# Altium schematic sheet POWER.SchDoc(POWER)
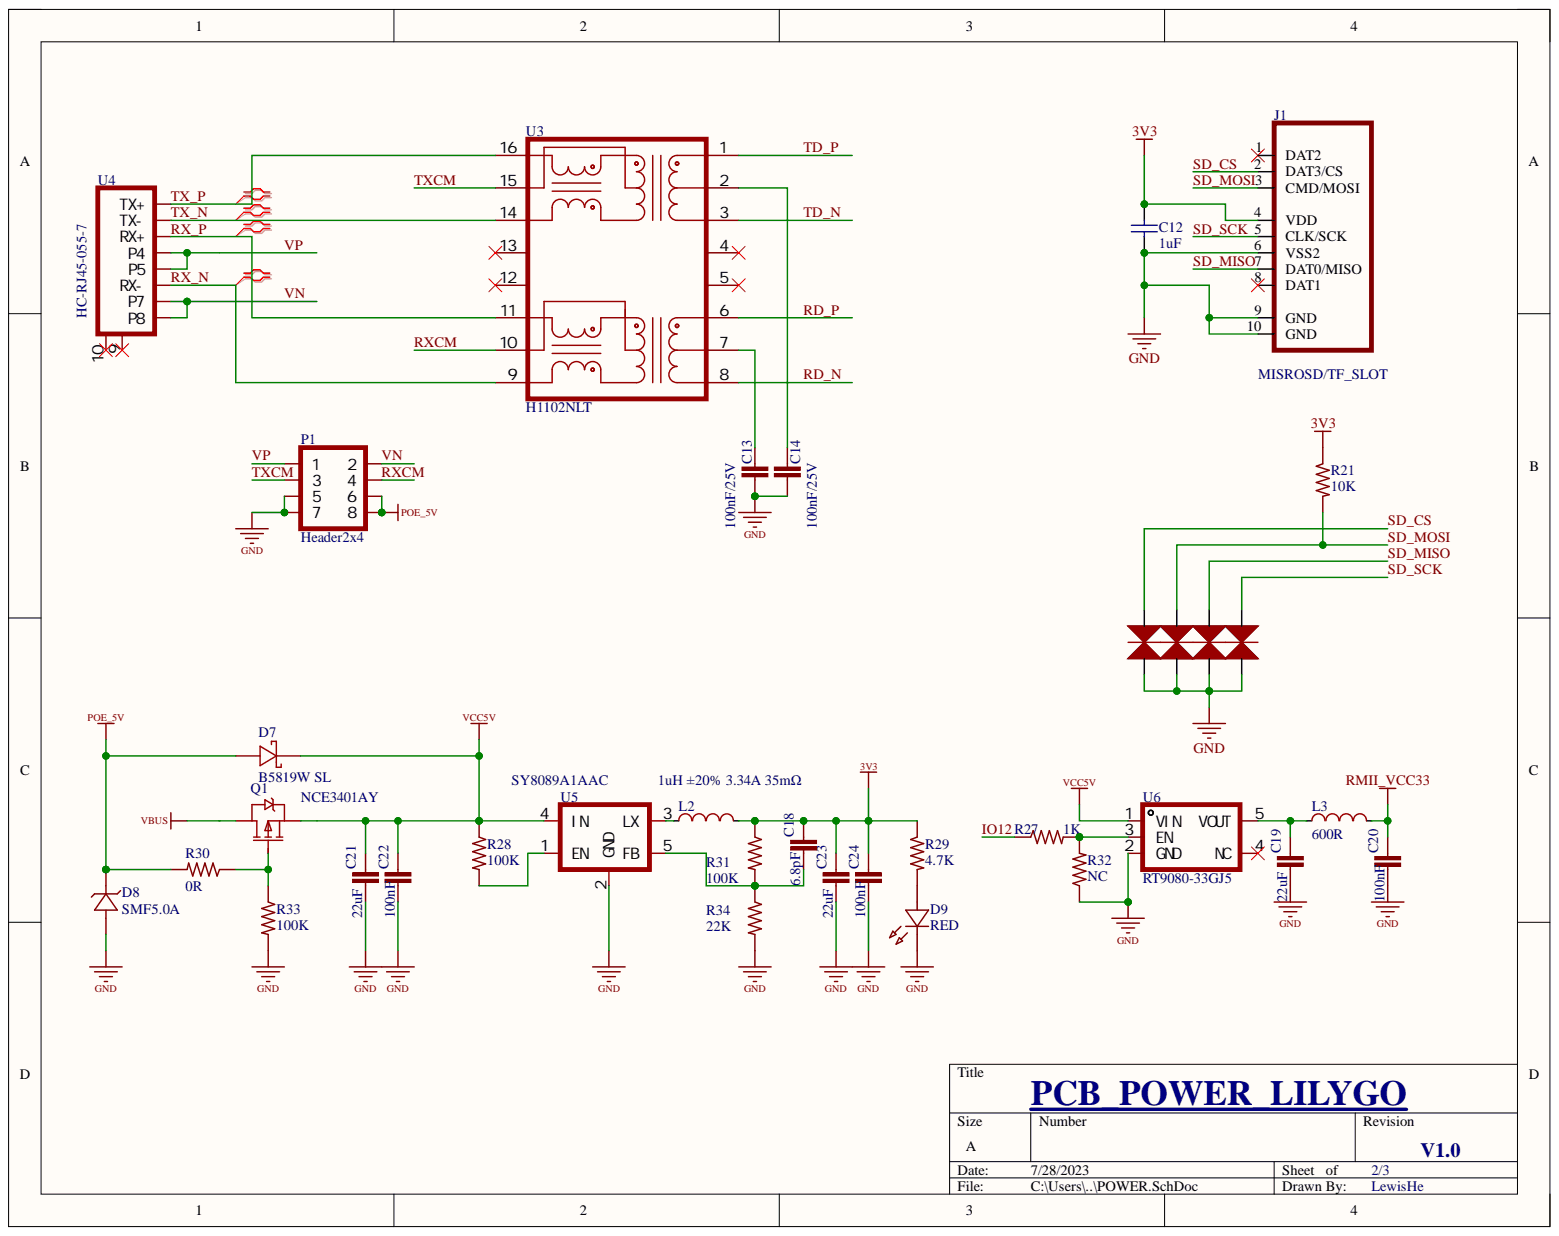
[diagram p0: POWER.SchDoc]

## Parts
C12  1uF  [C0402]
C13  100nF/25V  [c0603]
C14  100nF/25V  [c0603]
C18  6.8pF  [C0402]
C19  22uF  [C0603]
C20  100nF  [C0402]
C21  22uF  [C0603]
C22  100nF  [C0402]
C23  22uF  [C0603]
C24  100nF  [C0402]
D3  ESD05V88D-LC  [DFN-SMD_L1.0-W0.6-BI]  (value not drawn)
D4  ESD05V88D-LC  [DFN-SMD_L1.0-W0.6-BI]  (value not drawn)
D5  ESD05V88D-LC  [DFN-SMD_L1.0-W0.6-BI]  (value not drawn)
D6  ESD05V88D-LC  [DFN-SMD_L1.0-W0.6-BI]  (value not drawn)
D7  B5819W SL  [SOD-123_L2.7-W1.6-LS3.7-RD-1]
D8  SMF5.0A  [SOD-123FL_L2.7-W1.8-LS3.8-RD]
D9  RED  [LED0603_RED]
J1  MISROSD/TF_SLOT  [Micro_SD]
L2  1uH ±20% 3.34A 35mΩ  [IND-SMD_L3.2-W3.0_SPM3012T]
L3  600R  [L0603]
P1  Header2x4  [HDR-TH_8P-P2.54-V-F-R2-C4-S2.54-排针]
Q1  NCE3401AY  [SOT-23-3_L2.9-W1.6-P1.90-LS2.8-BR]
R21  10K  [R0402]
R27  1K  [R0402]
R28  100K  [R0402]
R29  4.7K  [R0402]
R30  0R  [R0402]
R31  100K  [R0402]
R32  NC  [R0402]
R33  100K  [R0402]
R34  22K  [R0402]
U3  H1102NLT  [XFMR-SMD_H1102NLT]
U4  HC-RJ45-055-7  [RJ45-SMD_HC-RJ45-055-7_1]
U5  SY8089A1AAC  [SOT-23-5_L2.9-W1.7-P0.95-LS2.8-BL]
U6  RT9080-33GJ5  [TSOT-23-5_L2.9-W1.6-P0.95-LS2.8-BL]

## Nets
3V3: C12.1 C18.2 C23.2 C24.2 J1.4(VDD) L2.2 R21.1 R29.1 R31.1
GND: C12.2 C13.1 C14.1 C19.1 C20.1 C21.1 C22.1 C23.1 C24.1 D3.2 D4.2 D5.2 D6.2 D8.2 D9.2 J1.6(VSS2) J1.9(GND) J1.10(GND) P1.5 P1.7 R32.1 R33.2 R34.2 U5.2(GND) U6.2(GND)
POE_5V: D7.2 D8.1 P1.6 P1.8 R30.2
RMII_VCC33: C20.2 L3.1
VBUS: Q1.3
VCC5V: C21.2 C22.2 D7.1 Q1.2 R28.1 U5.4(IN) U6.1(VIN)
IO12: R27.1
RD_N: U3.8
RD_P: U3.6
RX_N: U3.9 U4.6(RX-)
RX_P: U3.11 U4.3(RX+)
RXCM: P1.4 U3.10
SD_CS: D3.1 J1.2(DAT3/CS)
SD_MISO: D5.1 J1.7(DAT0/MISO)
SD_MOSI: D4.1 J1.3(CMD/MOSI) R21.2
SD_SCK: D6.1 J1.5(CLK/SCK)
TD_N: U3.3
TD_P: U3.1
TX_N: U3.14 U4.2(TX-)
TX_P: U3.16 U4.1(TX+)
TXCM: P1.3 U3.15
VN: P1.2 U4.7(P7) U4.8(P8)
VP: P1.1 U4.4(P4) U4.5(P5)
NetC13_2: C13.2 U3.7
NetC14_2: C14.2 U3.2
NetC18_1: C18.1 R31.2 R34.1 U5.5(FB)
NetC19_2: C19.2 L3.2 U6.5(VOUT)
NetD9_1: D9.1 R29.2
NetL2_1: L2.1 U5.3(LX)
NetQ1_1: Q1.1 R30.1 R33.1
NetR27_2: R27.2 R32.2 U6.3(EN)
NetR28_2: R28.2 U5.1(EN)

Not connected: J1 1(DAT2) 8(DAT1); U3 4 5 12 13; U4 9 10; U6 4(NC)

## Library data of the parts
C12 = Cap: Capacitor; Component Kind: Standard; LatestRevisionDate: 17-Jul-2002; LatestRevisionNote: Re-released for DXP Platform.; Library Name: Miscellaneous Devices.IntLib; PackageDescription: Radial Cap, Thru-Hole; 2 Leads; 0.3 in Pin Spacing; PackageReference: RAD-0.3; Published: 8-Jun-2000; Signal Integrity: Cap; Simulation: CAP; Value: 1uF; Publisher: Altium Limited
C13 C14 C18-C24 = C0201: 0201  贴片电容; Component Kind: Standard; Library Name: 常用.SCHLIB
D3-D6 = ESD05V88D-LC: 系统型号: YLSMT101670; Component Kind: Standard; Library Name: 常用.SCHLIB; 立创链接: https://item.szlcsc.com/5751019.html
D8 = SMF5.0A: TVS; Component Kind: Standard; Library Name: 常用.SCHLIB; 立创链接: https://item.szlcsc.com/231120.html
D9 = LED0603: Component Kind: Standard; Description: LED0603; Library Name: 常用.SCHLIB
J1 = MISROSD/TF_SLOT: TF卡短款-2.5/2.8高; 系统型号: YLSMT100809; Component Kind: Standard; Library Name: 常用.SCHLIB
L2 = CD32-1uH: 系统型号: 暂无; Component Kind: Standard; Description: 1uH ±20% 3.34A 35mΩ; Library Name: 常用.SCHLIB; 立创链接: https://item.szlcsc.com/2970820.html
L3 = L0603: 600R 0603 磁珠; Component Kind: Standard; Library Name: 常用.SCHLIB
P1 = Header 2x4: 2.54mm 2x4 排针; Component Kind: Standard; Library Name: 常用.SCHLIB
Q1 = NCE3401AY: P沟道 30V 4.4A; 系统型号: YLSMT101137; Component Kind: Standard; Library Name: 常用.SCHLIB; 立创链接: https://item.szlcsc.com/181200.html
R21 R27-R34 = R0201: Component Kind: Standard; Library Name: 常用.SCHLIB
U3 = H1102NLT: 百兆网络变压器; Component Kind: Standard; ComponentLink1Description: 立创链接; ComponentLink1URL: https://item.szlcsc.com/19286.html; Library Name: 常用.SCHLIB
U4 = HC-RJ45-055-7: 以太网连接器; Component Kind: Standard; ComponentLink1Description: 立创链接; ComponentLink1URL: https://item.szlcsc.com/3480996.html; Library Name: 常用.SCHLIB
U6 = RT9080-33GJ5: https://item.szlcsc.com/897967.html; Component Kind: Standard; Library Name: 常用.SCHLIB
D7 = B5819W SL: Component Kind: Standard; ComponentLink1Description: 立创链接; ComponentLink1URL: https://item.szlcsc.com/9093.html; Library Name: 常用.SCHLIB
U5 = SY8089A1AAC: Component Kind: Standard; Description: SY8089A1AAC; Library Name: 常用.SCHLIB
